# Altium schematic sheet connectors.SchDoc
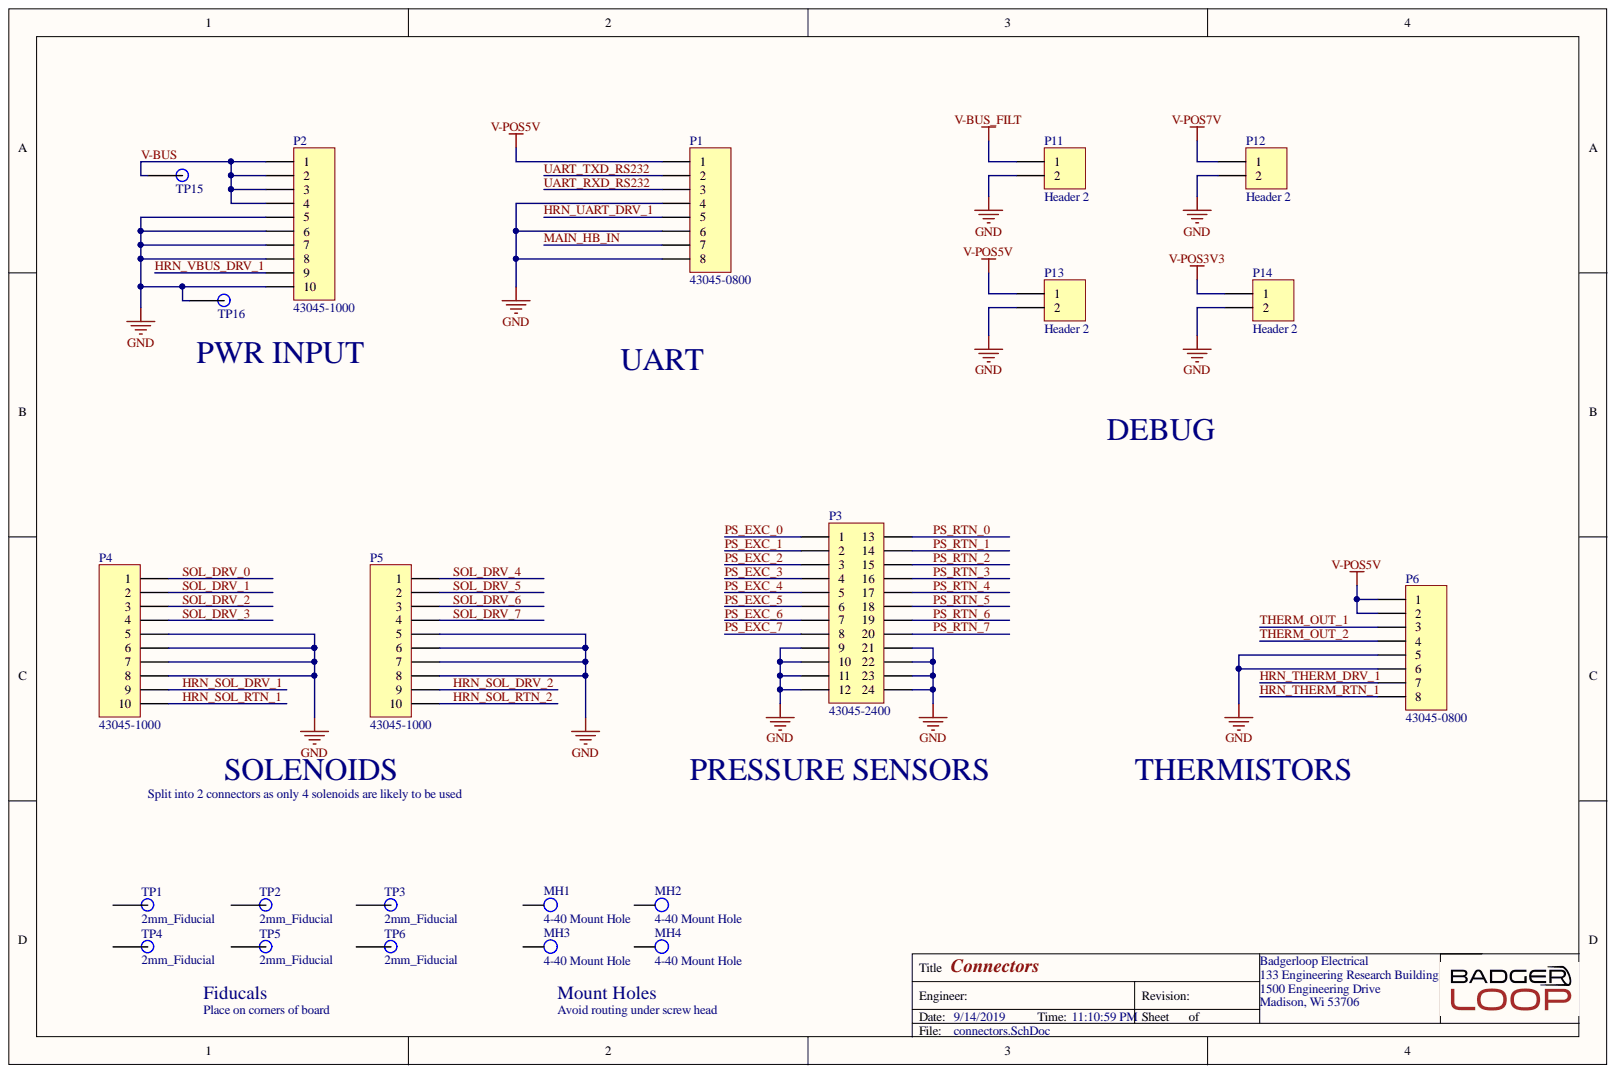
[diagram p0: connectors.SchDoc]

## Parts
MH1  4-40 Mount Hole  [MH]
MH2  4-40 Mount Hole  [MH]
MH3  4-40 Mount Hole  [MH]
MH4  4-40 Mount Hole  [MH]
P1  43045-0800  [430450800]
P2  43045-1000  [430451000]
P3  43045-2400  [430452400]
P4  43045-1000  [430451000]
P5  43045-1000  [430451000]
P6  43045-0800  [430450800]
P11  Header 2  [Pin Header 1x2 TH Pitch 2.54mm]
P12  Header 2  [Pin Header 1x2 TH Pitch 2.54mm]
P13  Header 2  [Pin Header 1x2 TH Pitch 2.54mm]
P14  Header 2  [Pin Header 1x2 TH Pitch 2.54mm]
TP1  2mm_Fiducial  [2mm_Fiducial]
TP2  2mm_Fiducial  [2mm_Fiducial]
TP3  2mm_Fiducial  [2mm_Fiducial]
TP4  2mm_Fiducial  [2mm_Fiducial]
TP5  2mm_Fiducial  [2mm_Fiducial]
TP6  2mm_Fiducial  [2mm_Fiducial]
TP15  TP  [Test Point]
TP16  TP  [Test Point]

## Nets
GND: P1.4 P1.6 P1.8 P2.5 P2.6 P2.7 P2.8 P2.10 P3.9 P3.10 P3.11 P3.12 P3.21 P3.22 P3.23 P3.24 P4.5 P4.6 P4.7 P4.8 P5.5 P5.6 P5.7 P5.8 P6.5 P6.6 P11.2 P12.2 P13.2 P14.2 TP16.1
V-BUS_FILT: P11.1
V-POS3V3: P14.1
V-POS5V: P1.1 P6.1 P6.2 P13.1
V-POS7V: P12.1
HRN_SOL_DRV_1: P4.9
HRN_SOL_DRV_2: P5.9
HRN_SOL_RTN_1: P4.10
HRN_SOL_RTN_2: P5.10
HRN_THERM_DRV_1: P6.7
HRN_THERM_RTN_1: P6.8
HRN_UART_DRV_1: P1.5
HRN_VBUS_DRV_1: P2.9
MAIN_HB_IN: P1.7
PS_EXC_0: P3.1
PS_EXC_1: P3.2
PS_EXC_2: P3.3
PS_EXC_3: P3.4
PS_EXC_4: P3.5
PS_EXC_5: P3.6
PS_EXC_6: P3.7
PS_EXC_7: P3.8
PS_RTN_0: P3.13
PS_RTN_1: P3.14
PS_RTN_2: P3.15
PS_RTN_3: P3.16
PS_RTN_4: P3.17
PS_RTN_5: P3.18
PS_RTN_6: P3.19
PS_RTN_7: P3.20
SOL_DRV_0: P4.1
SOL_DRV_1: P4.2
SOL_DRV_2: P4.3
SOL_DRV_3: P4.4
SOL_DRV_4: P5.1
SOL_DRV_5: P5.2
SOL_DRV_6: P5.3
SOL_DRV_7: P5.4
THERM_OUT_1: P6.3
THERM_OUT_2: P6.4
UART_RXD_RS232: P1.3
UART_TXD_RS232: P1.2
V-BUS: P2.1 P2.2 P2.3 P2.4 TP15.1

Not connected: MH1 46; MH2 46; MH3 46; MH4 46; TP1 1; TP2 1; TP3 1; TP4 1; TP5 1; TP6 1

## Library data of the parts
P1 P6 = 43045-0800: CONN HEADER R/A 8POS 3MM; Manufacturer: Molex; Approvals: UL,CSA,TUV; Contact Resistance: 10mR; Current Rating: 5A; Gender: Male; Glow Wire Compliant: Compliant; Insulation Resistance: 1GR; Max Voltage Rating (DC): 250.25kV; Number of Circuits: 8; Voltage Rating: 600V; Voltage Rating (AC): 250V; Case/Package: SMC; Color: Black; Component Kind: Standard; ComponentLink1Description: https://datasheet.ciiva.com/5158/0430450800-pcb-headers-142625-5158817.pdf?src-supplier=Mouser; ComponentLink1URL: https://datasheet.ciiva.com/5158/0430450800-pcb-headers-142625-5158817.pdf?src-supplier=Mouser; ComponentLink2Description: https://datasheet.ciiva.com/7683/27645-7683683.pdf?src-supplier=Element14; ComponentLink2URL: https://datasheet.ciiva.com/7683/27645-7683683.pdf?src-supplier=Element14; ComponentLink3Description: https://datasheet.ciiva.com/19462/883536-19462164.pdf?src-supplier=Jameco; ComponentLink3URL: https://datasheet.ciiva.com/19462/883536-19462164.pdf?src-supplier=Jameco; ComponentLink4Description: https://datasheet.ciiva.com/11751/0900766b80027348-11751142.pdf?src-supplier=RSComponents; ComponentLink4URL: https://datasheet.ciiva.com/11751/0900766b80027348-11751142.pdf?src-supplier=RSComponents; ComponentLink5Description: https://datasheet.ciiva.com/30198/267770430450800-pcb-headers-30198875.pdf?src-supplier=Onlinecomponents.com; ComponentLink5URL: https://datasheet.ciiva.com/30198/267770430450800-pcb-headers-30198875.pdf?src-supplier=Onlinecomponents.com; ComponentLink6Description: https://datasheet.ciiva.com/1443/1850016-1443537.pdf?src-supplier=Element14; ComponentLink6URL: https://datasheet.ciiva.com/1443/1850016-1443537.pdf?src-supplier=Element14; ComponentLink7Description: https://datasheet.ciiva.com/14155/04402310-14155206.pdf?src-supplier=Distrelec; ComponentLink7URL: https://datasheet.ciiva.com/14155/04402310-14155206.pdf?src-supplier=Distrelec; ComponentLink8Description: https://datasheet.ciiva.com/16657/0430450800-pcb-headers-16657964.pdf?src-supplier=Avnet+Europe; ComponentLink8URL: https://datasheet.ciiva.com/16657/0430450800-pcb-headers-16657964.pdf?src-supplier=Avnet+Europe; ComponentLink9Description: https://datasheet.ciiva.com/10087/0430450800-pcb-headers-10087853.pdf?src-supplier=Avnet; ComponentLink9URL: https://datasheet.ciiva.com/10087/0430450800-pcb-headers-10087853.pdf?src-supplier=Avnet; Contact Material: Brass,Tin; Contact Plating: Tin; Depth: 12.2mm; Diameter: 3mm; Flammability Rating: UL94 V-0; Halogen Free: Low Halogen; Height: 8.77mm; Housing Color: Black; Housing Material: Thermoplastic; Lead Free: Lead Free; Length: 16.15mm; Library Name: connector.SchLib; Manufacturer Part Number: 43045-0800; Material: Polyester; Max Current Rating: 5A; Max Operating Temperature: 105°C; Min Operating Temperature: -40°C; Mount: Through Hole; Number of Contacts: 8; Number of Poles: 8; Number of Positions: 8; Number of Rows: 2; Orientation: Right Angle; Package Quantity: 1440; Packaging: Tray; Pitch: 3mm; Pricing 1: 1=1.91000, 10=1.73100, 100=1.48390, 500=1.23656, 1000=1.05990, 5000=0.93978, 10000=0.91858 (USD); Radiation Hardening: No; REACH SVHC: Unknown; RoHS: Yes; Row Spacing: 3mm; Stock 1: 2632; Supplier 1: Digi-Key; Supplier Part Number 1: WM1816-ND; Termination: Solder; Voltage Rating (DC): 250V; Working Voltage: 250V
P2 P4 P5 = 43045-1000: GLOVE, POLYAMIDE, L/FREE, PU, SIZE 6 - More Details; Manufacturer: Molex; Approvals: UL,CSA,TUV; Connector Type: Power,Rectangular; Contact Resistance: 10mR; Current Rating: 5A; Gender: Male; Glow Wire Compliant: Compliant; Insulation Resistance: 1GR; Max Voltage Rating (DC): 250.25kV; Number of Circuits: 10; Pitch: 3mm; Voltage Rating: 250V; Color: Black; Component Kind: Standard; ComponentLink1Description: https://datasheet.ciiva.com/5150/0430451000-pcb-headers-143006-5150145.pdf?src-supplier=Mouser; ComponentLink1URL: https://datasheet.ciiva.com/5150/0430451000-pcb-headers-143006-5150145.pdf?src-supplier=Mouser; ComponentLink2Description: https://datasheet.ciiva.com/11749/0900766b80027348-11749233.pdf?src-supplier=RSComponents; ComponentLink2URL: https://datasheet.ciiva.com/11749/0900766b80027348-11749233.pdf?src-supplier=RSComponents; ComponentLink3Description: https://datasheet.ciiva.com/19461/883595-19461109.pdf?src-supplier=Jameco; ComponentLink3URL: https://datasheet.ciiva.com/19461/883595-19461109.pdf?src-supplier=Jameco; ComponentLink4Description: https://datasheet.ciiva.com/30068/153980430451000-pcb-headers-30068060.pdf?src-supplier=Onlinecomponents.com; ComponentLink4URL: https://datasheet.ciiva.com/30068/153980430451000-pcb-headers-30068060.pdf?src-supplier=Onlinecomponents.com; ComponentLink5Description: https://datasheet.ciiva.com/14155/04402310-14155207.pdf?src-supplier=Distrelec; ComponentLink5URL: https://datasheet.ciiva.com/14155/04402310-14155207.pdf?src-supplier=Distrelec; ComponentLink6Description: https://datasheet.ciiva.com/18454/70090797-18454139.pdf?src-supplier=Allied+Electronics; ComponentLink6URL: https://datasheet.ciiva.com/18454/70090797-18454139.pdf?src-supplier=Allied+Electronics; ComponentLink7Description: https://datasheet.ciiva.com/16658/0430451000-pcb-headers-16658413.pdf?src-supplier=Avnet+Europe; ComponentLink7URL: https://datasheet.ciiva.com/16658/0430451000-pcb-headers-16658413.pdf?src-supplier=Avnet+Europe; ComponentLink8Description: https://datasheet.ciiva.com/9468/0430451000-pcb-headers-9468495.pdf?src-supplier=Avnet; ComponentLink8URL: https://datasheet.ciiva.com/9468/0430451000-pcb-headers-9468495.pdf?src-supplier=Avnet; Contact Material: Brass,Tin; Contact Plating: Tin; Depth: 12.2mm; Diameter: 3mm; Flammability Rating: UL94 V-0; Halogen Free: Low Halogen; Height: 8.77mm; Housing Color: Black; Housing Material: Thermoplastic; Lead Free: Lead Free; Length: 19.15mm; Library Name: connector.SchLib; Manufacturer Part Number: 43045-1000; Material: Polyester; Max Current Rating: 5A; Max Operating Temperature: 105°C; Min Operating Temperature: -40°C; Mount: Through Hole,PCB; Number of Contacts: 10; Number of Poles: 10; Number of Positions: 10; Number of Rows: 2; Orientation: Right Angle; Package Quantity: 1224; Packaging: Tray; Pricing 1: 1=2.57000, 10=2.33100, 100=1.99790, 500=1.66496, 1000=1.42710, 5000=1.26536 (USD); Radiation Hardening: No; REACH SVHC: Unknown; RoHS: Yes; Row Spacing: 3mm; Stock 1: 4799; Supplier 1: Digi-Key; Supplier Part Number 1: WM1817-ND; Termination: Solder; Voltage Rating (AC): 250V; Voltage Rating (DC): 250V; Working Voltage: 250V
P3 = 43045-2400: Molex MICRO-FIT 3.0 Series, Series Number 43045, 3mm Pitch 24 Way 2 Row Right Angle PCB Header, Solder Termination, 5A; Approvals: UL,CSA,TUV; Contact Resistance: 10mR; Gender: Male; Glow Wire Compliant: Compliant; Insulation Resistance: 1GR; Max Voltage Rating (DC): 250.25kV; Number of Circuits: 24; Operating Supply Voltage: 250V; Voltage Rating: 600V; Color: Black; Component Kind: Standard; ComponentLink1Description: https://datasheet.ciiva.com/19461/800104-19461233.pdf?src-supplier=Jameco; ComponentLink1URL: https://datasheet.ciiva.com/19461/800104-19461233.pdf?src-supplier=Jameco; ComponentLink2Description: https://datasheet.ciiva.com/36179/144580430452400-pcb-headers-36179650.pdf?src-supplier=Onlinecomponents.com; ComponentLink2URL: https://datasheet.ciiva.com/36179/144580430452400-pcb-headers-36179650.pdf?src-supplier=Onlinecomponents.com; ComponentLink3Description: https://datasheet.ciiva.com/5156/0430452400-pcb-headers-5156852.pdf?src-supplier=Mouser; ComponentLink3URL: https://datasheet.ciiva.com/5156/0430452400-pcb-headers-5156852.pdf?src-supplier=Mouser; ComponentLink4Description: https://datasheet.ciiva.com/11748/0900766b80d6135b-11748645.pdf?src-supplier=RSComponents; ComponentLink4URL: https://datasheet.ciiva.com/11748/0900766b80d6135b-11748645.pdf?src-supplier=RSComponents; ComponentLink5Description: https://datasheet.ciiva.com/7839/1596512-7839674.pdf?src-supplier=Element14; ComponentLink5URL: https://datasheet.ciiva.com/7839/1596512-7839674.pdf?src-supplier=Element14; ComponentLink6Description: https://datasheet.ciiva.com/16657/0430452400-pcb-headers-16657916.pdf?src-supplier=Avnet+Europe; ComponentLink6URL: https://datasheet.ciiva.com/16657/0430452400-pcb-headers-16657916.pdf?src-supplier=Avnet+Europe; ComponentLink7Description: https://datasheet.ciiva.com/14155/04402310-14155214.pdf?src-supplier=Distrelec; ComponentLink7URL: https://datasheet.ciiva.com/14155/04402310-14155214.pdf?src-supplier=Distrelec; Contact Material: Brass,Tin; Contact Plating: Tin; Current Rating: 5A; Depth: 12.2mm; Flammability Rating: UL94 V-0; Halogen Free: Low Halogen; Height: 8.77mm; Housing Color: Black; Housing Material: Thermoplastic; Lead Free: Lead Free; Lead Pitch: 3mm; Length: 40.15mm; Library Name: connector.SchLib; Manufacturer: Molex; Manufacturer Part Number: 43045-2400; Max Current Rating: 5A; Max Operating Temperature: 105°C; Min Operating Temperature: -40°C; Mount: Through Hole; Number of Contacts: 24; Number of Poles: 24; Number of Positions: 24; Number of Rows: 2; Orientation: Right Angle; Package Quantity: 576; Packaging: Tray; Pitch: 3mm; Pricing 1: 1=6.20000, 10=5.95100, 100=4.95920, 576=4.21531, 1152=3.59542 (USD); Radiation Hardening: No; REACH SVHC: Unknown; RoHS: Yes; Row Spacing: 3mm; Stock 1: 970; Supplier 1: Digi-Key; Supplier Part Number 1: WM4728-ND; Termination: Solder; Voltage Rating (AC): 250V; Voltage Rating (DC): 250V; Working Voltage: 250V
P11-P14 = Header 2: Header, 2-Pin; Component Kind: Standard; LatestRevisionDate: 17-Jul-2002; LatestRevisionNote: Re-released for DXP Platform.; Library Name: connector.SchLib; Signal Integrity: Connector; Publisher: Altium Limited
TP15 TP16 = TP: SMD TEST POINT; Component Kind: Standard; Library Name: misc.SchLib
MH1-MH4 = 4-40 Mount Hole: Component Kind: Standard; Library Name: misc.SchLib
TP1-TP6 = 2mm_Fiducial: Component Kind: Standard; Library Name: misc.SchLib
